# Altium schematic sheet Main Board-main_board_pwr-v2.0.0.SchDoc("Main Board-main_board_pwr-v2.0.0")
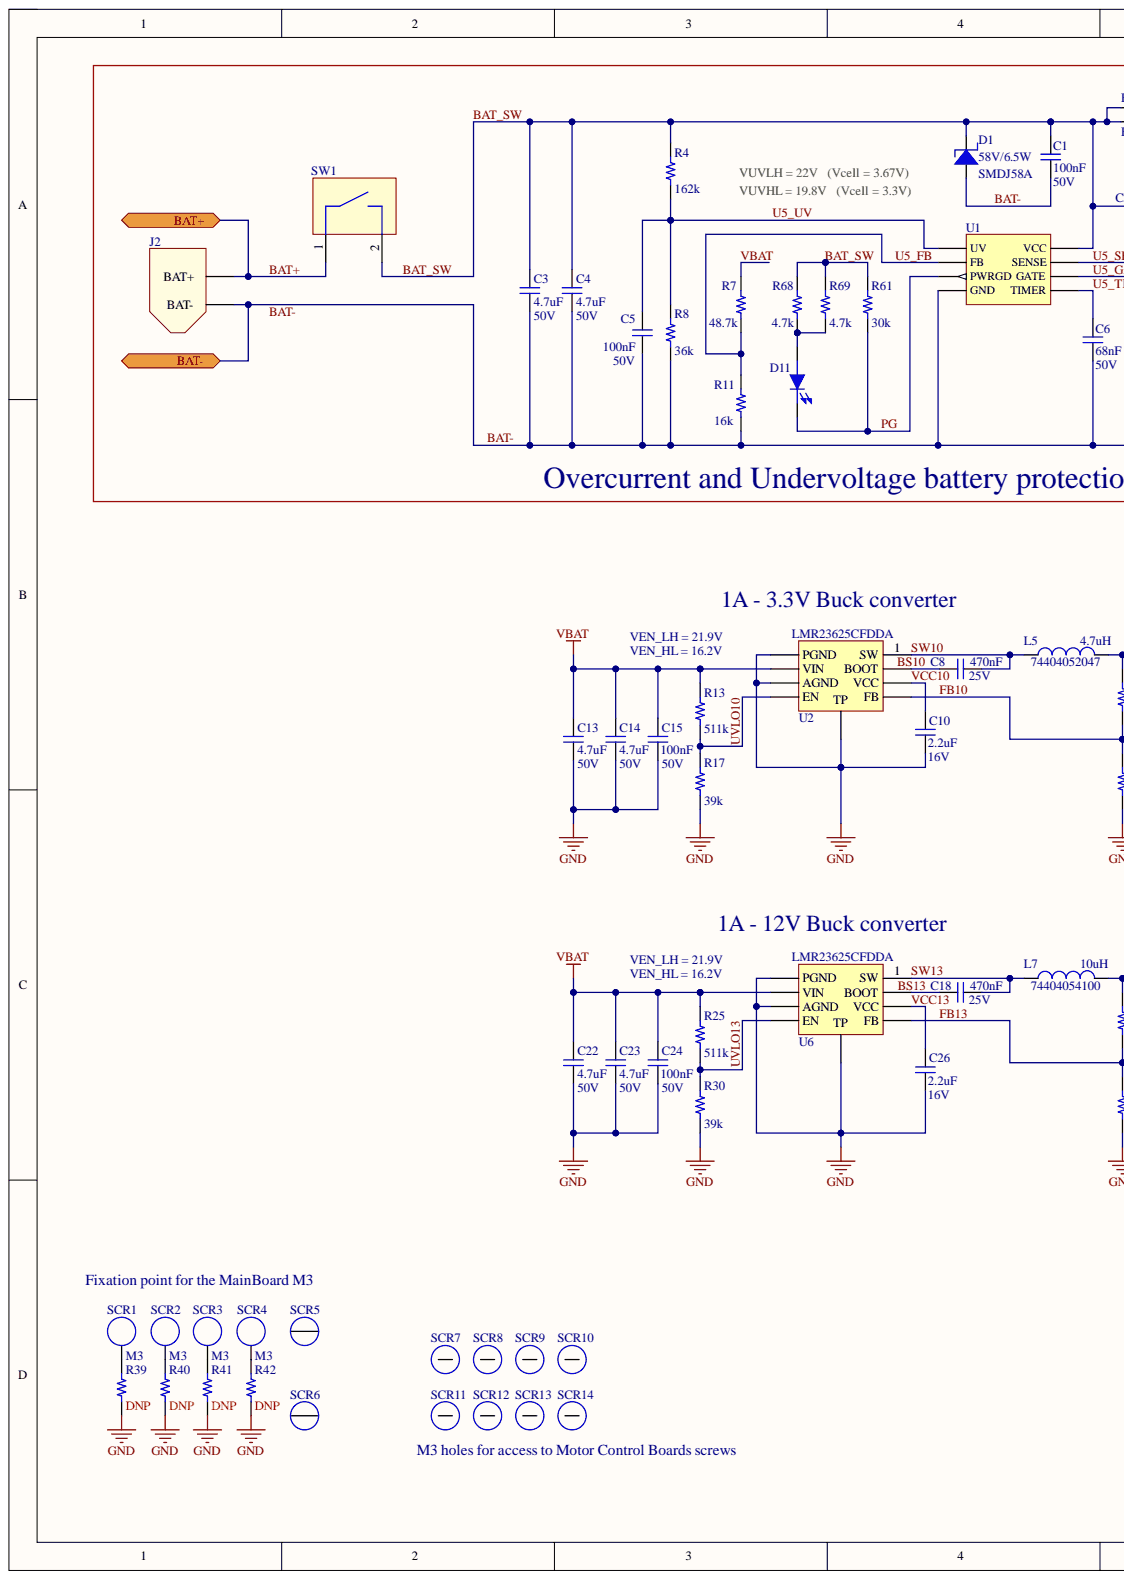
[diagram p0: Main Board-main_board_pwr-v2.0.0.SchDoc, left]
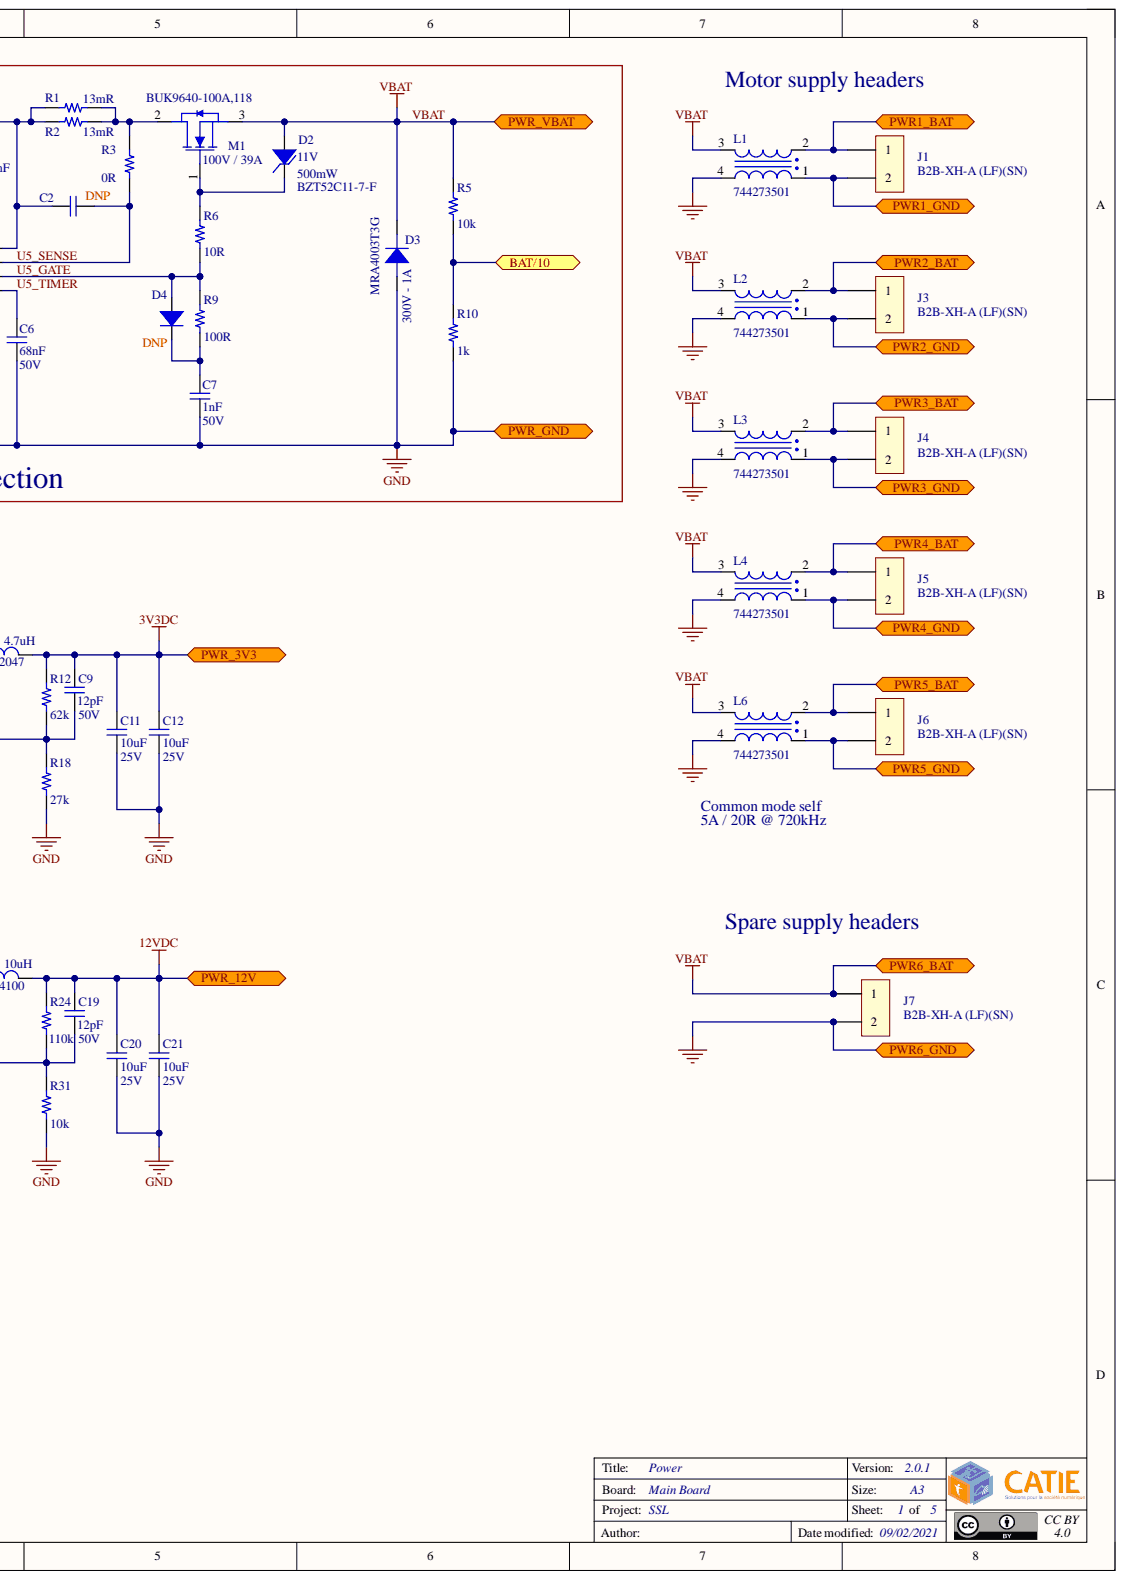
[diagram p1: Main Board-main_board_pwr-v2.0.0.SchDoc, right]

## Parts
C1  value 100nF  Rating: 50V  [CAP_CER_0603]  (hidden comment: CAP_CER_0603)
C2  Mount: DNP  [CAP_CER_0603]  (hidden comment: CAP_CER_0603)
C3  value 4.7uF  Rating: 50V  [CAP_CER_1206]  (hidden comment: CAPA_CER_1206)
C4  value 4.7uF  Rating: 50V  [CAP_CER_1206]  (hidden comment: CAPA_CER_1206)
C5  value 100nF  Rating: 50V  [CAP_CER_0603]  (hidden comment: CAP_CER_0603)
C6  value 68nF  Rating: 50V  [CAP_CER_0603]  (hidden comment: CAP_CER_0603)
C7  value 1nF  Rating: 50V  [CAP_CER_0603]  (hidden comment: CAP_CER_0603)
C8  value 470nF  Rating: 25V  [CAP_CER_0603]  (hidden comment: CAP_CER_0603)
C9  value 12pF  Rating: 50V  [CAP_CER_0402]  (hidden comment: CAP_CER_0402)
C10  value 2.2uF  Rating: 16V  [CAP_CER_0603]  (hidden comment: CAP_CER_0603)
C11  value 10uF  Rating: 25V  [CAP_CER_1206]  (hidden comment: CAPA_CER_1206)
C12  value 10uF  Rating: 25V  [CAP_CER_1206]  (hidden comment: CAPA_CER_1206)
C13  value 4.7uF  Rating: 50V  [CAP_CER_1206]  (hidden comment: CAPA_CER_1206)
C14  value 4.7uF  Rating: 50V  [CAP_CER_1206]  (hidden comment: CAPA_CER_1206)
C15  value 100nF  Rating: 50V  [CAP_CER_0402]  (hidden comment: CAP_CER_0402)
C18  value 470nF  Rating: 25V  [CAP_CER_0603]  (hidden comment: CAP_CER_0603)
C19  value 12pF  Rating: 50V  [CAP_CER_0402]  (hidden comment: CAP_CER_0402)
C20  value 10uF  Rating: 25V  [CAP_CER_1206]  (hidden comment: CAPA_CER_1206)
C21  value 10uF  Rating: 25V  [CAP_CER_1206]  (hidden comment: CAPA_CER_1206)
C22  value 4.7uF  Rating: 50V  [CAP_CER_1206]  (hidden comment: CAPA_CER_1206)
C23  value 4.7uF  Rating: 50V  [CAP_CER_1206]  (hidden comment: CAPA_CER_1206)
C24  value 100nF  Rating: 50V  [CAP_CER_0402]  (hidden comment: CAP_CER_0402)
C26  value 2.2uF  Rating: 16V  [CAP_CER_0603]  (hidden comment: CAP_CER_0603)
D1  value 58V/6.5W  Reference: SMDJ58A  [DIODE_TRANSIL_DO-214AB]  (hidden comment: DIODE_TRANSIL_DO-214AB)
D2  value 11V  Rating: 500mW  Reference: BZT52C11-7-F  [DIODE_ZENER_SOD123]  (hidden comment: DIODE_ZENER_SOD123)
D3  Rating: 300V - 1A  Reference: MRA4003T3G  [DIODE_SILICON_MRA4003T3G_ON-SEMI_SMA]  (hidden comment: DIODE_SILICON_MRA4003T3G_ON-SEMI_SMA)
D4  Mount: DNP  [DIODE_SILICON_SOD123]  (hidden comment: DIODE_SILICON_1N4148W-7-F_DIODES_SOD123)
D11  DIODE_LED_0603_TOP-VIEW  [DIODE_LED_0603_TOP-VIEW]  (value not drawn)
J1  HEADER_JST_B2B-XH-A_2.5MM-PITCH_2-PIN_TOP  [HEADER_JST_B2B-XH-A_2.5MM-PITCH_2-PIN_TOP]  (value not drawn)
J2  HEADER_XT60_MALE  [HEADER_XT60_MALE]  (value not drawn)
J3  HEADER_JST_B2B-XH-A_2.5MM-PITCH_2-PIN_TOP  [HEADER_JST_B2B-XH-A_2.5MM-PITCH_2-PIN_TOP]  (value not drawn)
J4  HEADER_JST_B2B-XH-A_2.5MM-PITCH_2-PIN_TOP  [HEADER_JST_B2B-XH-A_2.5MM-PITCH_2-PIN_TOP]  (value not drawn)
J5  HEADER_JST_B2B-XH-A_2.5MM-PITCH_2-PIN_TOP  [HEADER_JST_B2B-XH-A_2.5MM-PITCH_2-PIN_TOP]  (value not drawn)
J6  HEADER_JST_B2B-XH-A_2.5MM-PITCH_2-PIN_TOP  [HEADER_JST_B2B-XH-A_2.5MM-PITCH_2-PIN_TOP]  (value not drawn)
J7  HEADER_JST_B2B-XH-A_2.5MM-PITCH_2-PIN_TOP  [HEADER_JST_B2B-XH-A_2.5MM-PITCH_2-PIN_TOP]  (value not drawn)
L1  Reference: 744273501  [IND_FILTER_744273501_WE_COMMON-MODE-FILTER]  (hidden comment: Common Mode filter SMD)
L2  Reference: 744273501  [IND_FILTER_744273501_WE_COMMON-MODE-FILTER]  (hidden comment: Common Mode filter SMD)
L3  Reference: 744273501  [IND_FILTER_744273501_WE_COMMON-MODE-FILTER]  (hidden comment: Common Mode filter SMD)
L4  Reference: 744273501  [IND_FILTER_744273501_WE_COMMON-MODE-FILTER]  (hidden comment: Common Mode filter SMD)
L5  value 4.7uH  Reference: 74404052047  [IND_WURTH_74404052047_5X5X2]  (hidden comment: Inductor SMD)
L6  Reference: 744273501  [IND_FILTER_744273501_WE_COMMON-MODE-FILTER]  (hidden comment: Common Mode filter SMD)
L7  value 10uH  Reference: 74404054100  [IND_WURTH_74404054100_5X5X4]  (hidden comment: Inductor SMD)
M1  Rating: 100V / 39A  Reference: BUK9640-100A,118  [TR_MOSFETN_BUK9640_NXP_D2PAK]  (hidden comment: TR_MOSFETN_BUK9640_NXP_D2PAK)
R1  value 13mR  [RES_1206]  (hidden comment: RES_1206)
R2  value 13mR  [RES_1206]  (hidden comment: RES_1206)
R3  value 0R  [RES_0402]  (hidden comment: RES_0402)
R4  value 162k  [RES_0402]  (hidden comment: RES_0402)
R5  value 10k  [RES_0603]  (hidden comment: RES_0603)
R6  value 10R  [RES_0603]  (hidden comment: RES_0603)
R7  value 48.7k  [RES_0402]  (hidden comment: RES_0402)
R8  value 36k  [RES_0402]  (hidden comment: RES_0402)
R9  value 100R  [RES_0603]  (hidden comment: RES_0603)
R10  value 1k  [RES_0603]  (hidden comment: RES_0603)
R11  value 16k  [RES_0402]  (hidden comment: RES_0402)
R12  value 62k  [RES_0402]  (hidden comment: RES_0402)
R13  value 511k  [RES_0402]  (hidden comment: RES_0402)
R17  value 39k  [RES_0402]  (hidden comment: RES_0402)
R18  value 27k  [RES_0402]  (hidden comment: RES_0402)
R24  value 110k  [RES_0402]  (hidden comment: RES_0402)
R25  value 511k  [RES_0402]  (hidden comment: RES_0402)
R30  value 39k  [RES_0402]  (hidden comment: RES_0402)
R31  value 10k  [RES_0402]  (hidden comment: RES_0402)
R39  Mount: DNP  [RES_1210]  (hidden comment: RES_1210)
R40  Mount: DNP  [RES_1210]  (hidden comment: RES_1210)
R41  Mount: DNP  [RES_1210]  (hidden comment: RES_1210)
R42  Mount: DNP  [RES_1210]  (hidden comment: RES_1210)
R61  value 30k  [RES_0402]  (hidden comment: RES_0402)
R68  value 4.7k  [RES_0402]  (hidden comment: RES_0402)
R69  value 4.7k  [RES_0402]  (hidden comment: RES_0402)
SCR1  value M3  [SCREW_M3_PLATED_INTERCONNECT]  (hidden comment: SCREW_M3_PLATED_INTERCONNECT)  (no BOM)
SCR2  value M3  [SCREW_M3_PLATED_INTERCONNECT]  (hidden comment: SCREW_M3_PLATED_INTERCONNECT)  (no BOM)
SCR3  value M3  [SCREW_M3_PLATED_INTERCONNECT]  (hidden comment: SCREW_M3_PLATED_INTERCONNECT)  (no BOM)
SCR4  value M3  [SCREW_M3_PLATED_INTERCONNECT]  (hidden comment: SCREW_M3_PLATED_INTERCONNECT)  (no BOM)
SCR5  value M3  [SCREW_M3_PLATED]  (hidden comment: SCREW_M3_PLATED)  (no BOM)
SCR6  Mount: DNP  [SCREW_M3_PLATED]  (hidden comment: SCREW_M3_PLATED)  (no BOM)
SCR7  MECA_M3_HOME_NON-PLATED  [MECA_M3_HOME_NON-PLATED]  (value not drawn)  (no BOM)
SCR8  MECA_M3_HOME_NON-PLATED  [MECA_M3_HOME_NON-PLATED]  (value not drawn)  (no BOM)
SCR9  MECA_M3_HOME_NON-PLATED  [MECA_M3_HOME_NON-PLATED]  (value not drawn)  (no BOM)
SCR10  MECA_M3_HOME_NON-PLATED  [MECA_M3_HOME_NON-PLATED]  (value not drawn)  (no BOM)
SCR11  value M3  [MECA_M3_HOME_NON-PLATED]  (hidden comment: MECA_M3_HOME_NON-PLATED)  (no BOM)
SCR12  MECA_M3_HOME_NON-PLATED  [MECA_M3_HOME_NON-PLATED]  (value not drawn)  (no BOM)
SCR13  MECA_M3_HOME_NON-PLATED  [MECA_M3_HOME_NON-PLATED]  (value not drawn)  (no BOM)
SCR14  MECA_M3_HOME_NON-PLATED  [MECA_M3_HOME_NON-PLATED]  (value not drawn)  (no BOM)
SW1  SWITCH_RA1H1C112R_SPST_TH_HORIZONTAL  [SWITCH_RA1H1C112R_SPST_TH_HORIZONTAL]  (value not drawn)
U1  IC_LT4256_LINEAR_POSITIVE_HOT-SWAP_CONTROLLER_SOIC8  [IC_LT4256_LINEAR_POSITIVE_HOT-SWAP_CONTROLLER_SOIC8]  (value not drawn)
U2  Reference: LMR23625CFDDA  [IC_LMR23625CDDA_TI_SYNCHRONOUS-BUCK-CONVERTER_HSOIC8]  (hidden comment: IC_LMR23625CDDA_TI_SYNCHRONOUS-BUCK-CONVERTER_HSOIC8)
U6  Reference: LMR23625CFDDA  [IC_LMR23625CDDA_TI_SYNCHRONOUS-BUCK-CONVERTER_HSOIC8]  (hidden comment: IC_LMR23625CDDA_TI_SYNCHRONOUS-BUCK-CONVERTER_HSOIC8)

## Ports
BAT+, BAT-, BAT/10, PWR1_BAT, PWR1_GND, PWR2_BAT, PWR2_GND, PWR3_BAT, PWR3_GND, PWR4_BAT, PWR4_GND, PWR5_BAT, PWR5_GND, PWR6_BAT, PWR6_GND, PWR_3V3, PWR_12V, PWR_GND, PWR_VBAT

## Nets
3V3DC: C9.2 C11.2 C12.2 L5.1 R12.2 ; port PWR_3V3
12VDC: C19.2 C20.2 C21.2 L7.1 R24.2 ; port PWR_12V
BAT+: J2.2 SW1.1 ; port BAT+
BAT-: C1.2 C3.1 C4.1 C5.2 C6.2 C7.2 C10.1 C11.1 C12.1 C13.1 C14.1 C15.1 C20.1 C21.1 C22.1 C23.1 C24.1 C26.1 D1.2 D3.2 J2.1 J7.2 L1.4 L2.4 L3.4 L4.4 L6.4 R8.1 R10.1 R11.1 R17.1 R18.1 R30.1 R31.1 R39.1 R40.1 R41.1 R42.1 U1.4(GND) U2.6(AGND) U2.8(PGND) U2.9(TP) U6.6(AGND) U6.8(PGND) U6.9(TP) ; port BAT-, port PWR6_GND, port PWR_GND
BAT/10: R5.1 R10.2 ; port BAT/10
BAT_SW: C1.1 C2.1 C3.2 C4.2 D1.1 R1.1 R2.1 R4.2 R61.2 R68.2 R69.2 SW1.2 U1.8(VCC)
BS10: C8.2 U2.2(BOOT)
BS13: C18.2 U6.2(BOOT)
FB10: C9.1 R12.1 R18.2 U2.4(FB)
FB13: C19.1 R24.1 R31.2 U6.4(FB)
PG: D11.1 R61.1 U1.3(PWRGD)
PWR1_BAT: J1.1 L1.2 ; port PWR1_BAT
PWR1_GND: J1.2 L1.1 ; port PWR1_GND
PWR2_BAT: J3.1 L2.2 ; port PWR2_BAT
PWR2_GND: J3.2 L2.1 ; port PWR2_GND
PWR3_BAT: J4.1 L3.2 ; port PWR3_BAT
PWR3_GND: J4.2 L3.1 ; port PWR3_GND
PWR4_BAT: J5.1 L4.2 ; port PWR4_BAT
PWR4_GND: J5.2 L4.1 ; port PWR4_GND
PWR5_BAT: J6.1 L6.2 ; port PWR5_BAT
PWR5_GND: J6.2 L6.1 ; port PWR5_GND
SW10: C8.1 L5.2 U2.1(SW)
SW13: C18.1 L7.2 U6.1(SW)
U5_FB: R7.1 R11.2 U1.2(FB)
U5_GATE: D4.2 R6.1 R9.2 U1.6(GATE)
U5_SENSE: C2.2 R3.1 U1.7(SENSE)
U5_TIMER: C6.1 U1.5(TIMER)
U5_UV: C5.1 R4.1 R8.2 U1.1(UV)
UVLO10: R13.1 R17.2 U2.5(EN)
UVLO13: R25.1 R30.2 U6.5(EN)
VBAT: C13.2 C14.2 C15.2 C22.2 C23.2 C24.2 D2.2 D3.1 J7.1 L1.3 L2.3 L3.3 L4.3 L6.3 M1.3 R5.2 R7.2 R13.2 R25.2 U2.7(VIN) U6.7(VIN) ; port PWR6_BAT, port PWR_VBAT
VCC10: C10.2 U2.3(VCC)
VCC13: C26.2 U6.3(VCC)
NetC7_1: C7.1 D4.1 R9.1
NetD2_1: D2.1 M1.1 R6.2
NetD11_2: D11.2 R68.1 R69.1
NetM1_2: M1.2 R1.2 R2.2 R3.2
NetR39_2: R39.2 SCR1.1
NetR40_2: R40.2 SCR2.1
NetR41_2: R41.2 SCR3.1
NetR42_2: R42.2 SCR4.1

Not connected: SCR5 1; SCR6 1; SCR7 1; SCR8 1; SCR9 1; SCR10 1; SCR11 1; SCR12 1; SCR13 1; SCR14 1

## Library data of the parts
C1 C5 = CAP_CER_0603: Capacitor ceramics SMD 0603; Manufacturer: Samsung; Ceram: X7R; Reference: CL10B104KB8NNNC; Tol: 10%; Case: 0603; Component Kind: Standard; Library Name: Schematic_Components.SchLib; Mount: Mnt; Technology: CMS; Type: Capacitor
C2 = CAP_CER_0603: Capacitor ceramics SMD 0603; Manufacturer: Wurth Elektronik; Ceram: X7R; Rating: 50V; Tol: 10%; Value: F; Case: 0603; Component Kind: Standard; Library Name: Schematic_Components.SchLib; Technology: CMS; Type: Capacitor
C3 C4 C13 C14 C22 C23 = CAP_CER_1206: Capacitor ceramics SMD 1206; Manufacturer: Taiyo Yuden; Ceram: X5R; Reference: UMK316BJ475KL-T; Tol: 10%; Case: 1206; Component Kind: Standard; Library Name: Schematic_Components.SchLib; Mount: Mnt; Technology: CMS; Type: Capacitor
C6 = CAP_CER_0603: Capacitor ceramics SMD 0603; Manufacturer: Wurth Elektronik; Ceram: X7R; Reference: 885012206094; Tol: 10%; Case: 0603; Component Kind: Standard; Library Name: Schematic_Components.SchLib; Mount: Mnt; Technology: CMS; Type: Capacitor
C7 = CAP_CER_0603: Capacitor ceramics SMD 0603; Manufacturer: Wurth Elektronik; Ceram: X7R; Reference: 885012206083; Tol: 10%; Case: 0603; Component Kind: Standard; Library Name: Schematic_Components.SchLib; Mount: Mnt; Technology: CMS; Type: Capacitor
C8 C18 = CAP_CER_0603: Capacitor ceramics SMD 0603; Manufacturer: Samsung; Ceram: X7R; Reference: CL10B474KA8NFNC; Tol: 10%; Case: 0603; Component Kind: Standard; Library Name: Schematic_Components.SchLib; Mount: Mnt; Technology: CMS; Type: Capacitor
C9 C19 = CAP_CER_0402: Capacitor ceramics SMD 0402; Manufacturer: Yageo; Ceram: C0G, NP0; Reference: CC0402JRNPO9BN120; Tol: 5%; Case: 0402; Component Kind: Standard; Library Name: Schematic_Components.SchLib; Mount: Mnt; Technology: CMS; Type: Capacitor
C10 C26 = CAP_CER_0603: Capacitor ceramics SMD 0603; Manufacturer: Samsung; Ceram: X5R; Reference: CL10A225KO8NNNC; Tol: 10%; Case: 0603; Component Kind: Standard; Library Name: Schematic_Components.SchLib; Mount: Mnt; Technology: CMS; Type: Capacitor
C11 C12 C20 C21 = CAP_CER_1206: Capacitor ceramics SMD 1206; Manufacturer: Samsung; Ceram: X5R; Reference: CL31A106KAHNNNE; Tol: 10%; Case: 1206; Component Kind: Standard; Library Name: Schematic_Components.SchLib; Mount: Mnt; Technology: CMS; Type: Capacitor
C15 C24 = CAP_CER_0402: Capacitor ceramics SMD 0402; Manufacturer: Murata; Ceram: X5R; Reference: GCM155R71H104KE02D; Tol: 10%; Case: 0402; Component Kind: Standard; Library Name: Schematic_Components.SchLib; Mount: Mnt; Technology: CMS; Type: Capacitor
D1 = DIODE_TRANSIL_DO-214AB: Transil diode SMD SMC / DO-214AB; Manufacturer: Littelfuse; Rating: 3000W; Case: DO-214AB (SMC); Component Kind: Standard; Library Name: MainBoard_Schlib.SchLib; Mount: Mnt; Technology: CMS; Type: Diode
D2 = DIODE_ZENER_SOD123: Zener diode SMD SOD123; Manufacturer: Diodes; Case: SOD132; Component Kind: Standard; Library Name: MainBoard_Schlib.SchLib; Mount: Mnt; Technology: CMS; Type: Diode
D3 = DIODE_SILICON_MRA4003T3G_ON-SEMI_SMA: General purpose Silicon diode SMA; Manufacturer: On Semiconductors; Value: 1.1V; Case: DO-214AB - SMC; Component Kind: Standard; Library Name: MainBoard_Schlib.SchLib; Mount: Mnt; Technology: CMS; Type: Diode
D4 = DIODE_SILICON_1N4148W-7-F_DIODES_SOD123: Standard Silicon diode SOD123; Manufacturer: Diodes; Rating: 100V - 300mA; Value: 1.25V; Case: SOD123; Component Kind: Standard; Library Name: MainBoard_Schlib.SchLib; Reference: 1N4148W-7-F; Technology: CMS; Type: Diode
D11 = DIODE_LED_0603_TOP-VIEW: LED SMD 0603 Top view; Manufacturer: Wurth Elektronik; Colour: Red; Rating: 20mA; Reference: 150060RS55040; Value: 2V; Case: 0603; Component Kind: Standard; Mount: Mnt; Technology: CMS; Type: Diode_LED
J1 J3-J7 = HEADER_JST_B2B-XH-A_2.5MM-PITCH_2-PIN_TOP: Header JST B2B-XH-A 2.5mm pitch 2-pin vertical for batteries; Mating Housing: XHP-2; Pre-criped cable 150mm: 01SXHSXH-26L150; Pre-criped cable 300mm: 01SXHSXH-26L300; Rating: 250V - 3A; Reference: B2B-XH-A (LF)(SN); Component Kind: Standard; Library Name: MainBoard_Schlib.SchLib; Manufacturer: JST; Mount: Mnt; Technology: TRAD; Type: Header; Value: 2.5mm
J2 = HEADER_XT60_MALE: Battery Header XT60; Manufacturer: G-Force; Hight: 16mm; Rating: 60A; Component Kind: Standard; Gender: Header; Library Name: MainBoard_Schlib.SchLib; Mount: Mnt; Reference: XT60; Technology: TH; Type: Header
L1-L4 L6 = IND_FILTER_744273501_WE_COMMON-MODE-FILTER: Common Mode Line Filter for DC power supply; Manufacturer: Wurth Elektronik; Rating: 5A / 80V; Tol: 20%; Type: Inductor; Value: 2x5uH; Case: 9.5 x 8.3 x 5.3mm; Component Kind: Standard; Library Name: MainBoard_Schlib.SchLib; Mount: Mnt; Technology: CMS
L5 = IND_WURTH_74404052047_5X5X2: Inductor SMD shielded 5x5x2; Manufacturer: Wurth Elektronik; Rating: 2.3A; Tol: 20%; Case: 5 x 5 x 2mm; Component Kind: Standard; Library Name: MainBoard_Schlib.SchLib; Mount: Mnt; Technology: CMS; Type: Inductor
L7 = IND_WURTH_74404054100_5X5X4: Inductor SMD shielded 5x5x4; Manufacturer: Wurth Elektronik; Rating: 2.1A; Tol: 20%; Case: 5 x 5 x 4mm; Component Kind: Standard; Library Name: MainBoard_Schlib.SchLib; Mount: Mnt; Technology: CMS; Type: Inductor
M1 = TR_MOSFETN_BUK9640_NXP_D2PAK: MOSFET N D2-PAK 3-Pads; Manufacturer: Nexperia; Value: 43mR; Case: D2PAK-SOT404; Component Kind: Standard; Library Name: MainBoard_Schlib.SchLib; Mount: Mnt; Technology: CMS; Type: Transistor
R1 R2 = RES_1206: Resistor SMD 1206; Manufacturer: TE Comnnectivity; Rating: 0.5W; Reference: RLP73V2BR013FTDF; Tol: 1%; Case: 1206; Component Kind: Standard; Library Name: Schematic_Components.SchLib; Mount: Mnt; Technology: CMS; Type: Resistor
R3 = RES_0402: Resistor SMD 0402; Manufacturer: Yageo; Rating: 1/16W; Reference: RC0402FR-070RL; Case: 0402; Component Kind: Standard; Library Name: Schematic_Components.SchLib; Mount: Mnt; Technology: CMS; Type: Resistor
R4 = RES_0402: Resistor SMD 0402; Manufacturer: Yageo; Rating: 1/16W; Reference: RC0402FR-07162KL; Tol: 1%; Case: 0402; Component Kind: Standard; Library Name: Schematic_Components.SchLib; Mount: Mnt; Technology: CMS; Type: Resistor
R5 = RES_0603: Resistor SMD 0603; Manufacturer: Yageo; Rating: 0.1W; Reference: RC0603FR-0710KL; Tol: 1%; Case: 0603; Component Kind: Standard; Library Name: Schematic_Components.SchLib; Mount: Mnt; Technology: CMS; Type: Resistor
R6 = RES_0603: Resistor SMD 0603; Manufacturer: Yageo; Rating: 0.1W; Reference: RC0603FR-0710RL; Tol: 1%; Case: 0603; Component Kind: Standard; Library Name: Schematic_Components.SchLib; Mount: Mnt; Technology: CMS; Type: Resistor
R7 = RES_0402: Resistor SMD 0402; Manufacturer: Yageo; Rating: 1/16W; Reference: RC0402FR-0748K7L; Tol: 1%; Case: 0402; Component Kind: Standard; Library Name: Schematic_Components.SchLib; Mount: Mnt; Technology: CMS; Type: Resistor
R8 = RES_0402: Resistor SMD 0402; Manufacturer: Yageo; Rating: 1/16W; Reference: RC0402FR-0736KL; Tol: 1%; Case: 0402; Component Kind: Standard; Library Name: Schematic_Components.SchLib; Mount: Mnt; Technology: CMS; Type: Resistor
R9 = RES_0603: Resistor SMD 0603; Manufacturer: Yageo; Rating: 0.1W; Reference: RC0603FR-07100RL; Tol: 1%; Case: 0603; Component Kind: Standard; Library Name: Schematic_Components.SchLib; Mount: Mnt; Technology: CMS; Type: Resistor
R10 = RES_0603: Resistor SMD 0603; Manufacturer: Yageo; Rating: 0.1W; Reference: RC0603FR-071KL; Tol: 1%; Case: 0603; Component Kind: Standard; Library Name: Schematic_Components.SchLib; Mount: Mnt; Technology: CMS; Type: Resistor
R11 = RES_0402: Resistor SMD 0402; Manufacturer: Yageo; Rating: 1/16W; Reference: RC0402FR-0716KL; Tol: 1%; Case: 0402; Component Kind: Standard; Library Name: Schematic_Components.SchLib; Mount: Mnt; Technology: CMS; Type: Resistor
R12 = RES_0402: Resistor SMD 0402; Manufacturer: Yageo; Rating: 1/16W; Reference: RC0402FR-0762KL; Tol: 1%; Case: 0402; Component Kind: Standard; Library Name: Schematic_Components.SchLib; Mount: Mnt; Technology: CMS; Type: Resistor
R13 R25 = RES_0402: Resistor SMD 0402; Manufacturer: Yageo; Rating: 1/16W; Reference: RC0402FR-07511KL; Tol: 1%; Case: 0402; Component Kind: Standard; Library Name: Schematic_Components.SchLib; Mount: Mnt; Technology: CMS; Type: Resistor
R17 R30 = RES_0402: Resistor SMD 0402; Manufacturer: Yageo; Rating: 1/16W; Reference: RC0402FR-0739KL; Tol: 1%; Case: 0402; Component Kind: Standard; Library Name: Schematic_Components.SchLib; Mount: Mnt; Technology: CMS; Type: Resistor
R18 = RES_0402: Resistor SMD 0402; Manufacturer: Yageo; Rating: 1/16W; Reference: RC0402FR-0727KL; Tol: 1%; Case: 0402; Component Kind: Standard; Library Name: Schematic_Components.SchLib; Mount: Mnt; Technology: CMS; Type: Resistor
R24 = RES_0402: Resistor SMD 0402; Manufacturer: Yageo; Rating: 1/16W; Reference: RC0402FR-07110KL; Tol: 1%; Case: 0402; Component Kind: Standard; Library Name: Schematic_Components.SchLib; Mount: Mnt; Technology: CMS; Type: Resistor
R31 = RES_0402: Resistor SMD 0402; Manufacturer: Yageo; Rating: 1/16W; Reference: RC0402FR-0710KL; Tol: 1%; Case: 0402; Component Kind: Standard; Library Name: Schematic_Components.SchLib; Mount: Mnt; Technology: CMS; Type: Resistor
R39-R42 = RES_1210: Resistor SMD 1210; Manufacturer: Yageo; Rating: 0.5W; Reference: RC1210FR-071KL; Value: 0R; Case: 1210; Component Kind: Standard; Library Name: Schematic_Components.SchLib; Technology: CMS; Type: Resistor
R61 = RES_0402: Resistor SMD 0402; Manufacturer: Yageo; Rating: 1/16W; Reference: RC0402FR-0730KL; Tol: 1%; Case: 0402; Component Kind: Standard; Library Name: Schematic_Components.SchLib; Mount: Mnt; Technology: CMS; Type: Resistor
R68 R69 = RES_0402: Resistor SMD 0402; Manufacturer: Vishay Dale; Rating: 1/5W; Reference: RCS04024K70FKED; Tol: 1%; Case: 0402; Component Kind: Standard; Library Name: Schematic_Components.SchLib; Mount: Mnt; Technology: CMS; Type: Resistor
SCR1-SCR4 = SCREW_M3_PLATED_INTERCONNECT: Screw M3 with 6mm diameter head and possible ground connect; Mount: DNP; Library Name: MainBoard_Schlib.SchLib; Technology: TRAD; Type: Meca
SCR5 = SCREW_M3_PLATED: Screw M3 with 6mm diameter head; Mount: DNP; Library Name: MainBoard_Schlib.SchLib; Technology: TRAD; Type: Meca
SCR6 = SCREW_M3_PLATED: Screw M3 with 6mm diameter head; Library Name: MainBoard_Schlib.SchLib; Technology: TRAD; Type: Meca; Value: M3
SCR7-SCR14 = MECA_M3_HOME_NON-PLATED: M3 Hole non plated; Mount: DNP; Library Name: MainBoard_Schlib.SchLib; Technology: TRAD; Type: Meca
  SCR7-SCR10 SCR12-SCR14: Value: M3
SW1 = SWITCH_RA1H1C112R_SPST_TH_HORIZONTAL: Rocker switch SPST right angle through-hole panel-mount; Manufacturer: E-Switch; Hight: 15mm; Rating: 16A/125VAC; Component Kind: Standard; Library Name: MainBoard_Schlib.SchLib; Mount: Mnt; Reference: RA1H1C112R; Technology: TH; Type: Switch
U1 = IC_LT4256_LINEAR_POSITIVE_HOT-SWAP_CONTROLLER_SOIC8: Positive high voltage hot swap controller in SOIC8; Manufacturer: Analog Device; Rating: 10.8-80V; Reference: LT4256-2CS8#PBF; Case: SOIC8; Component Kind: Standard; Library Name: MainBoard_Schlib.SchLib; Mount: Mnt; Technology: CMS; Type: IC
U2 U6 = IC_LMR23625CDDA_TI_SYNCHRONOUS-BUCK-CONVERTER_HSOIC8: Synchronous-mode Buck converter HSOIC8; Manufacturer: Texas Instruments; Rating: 36V - 2.5A; Value: 2.1MHz; Case: HSOIC8; Component Kind: Standard; Library Name: MainBoard_Schlib.SchLib; Mount: Mnt; Technology: CMS; Type: IC
